# Altium schematic sheet CPU_1.SchDoc
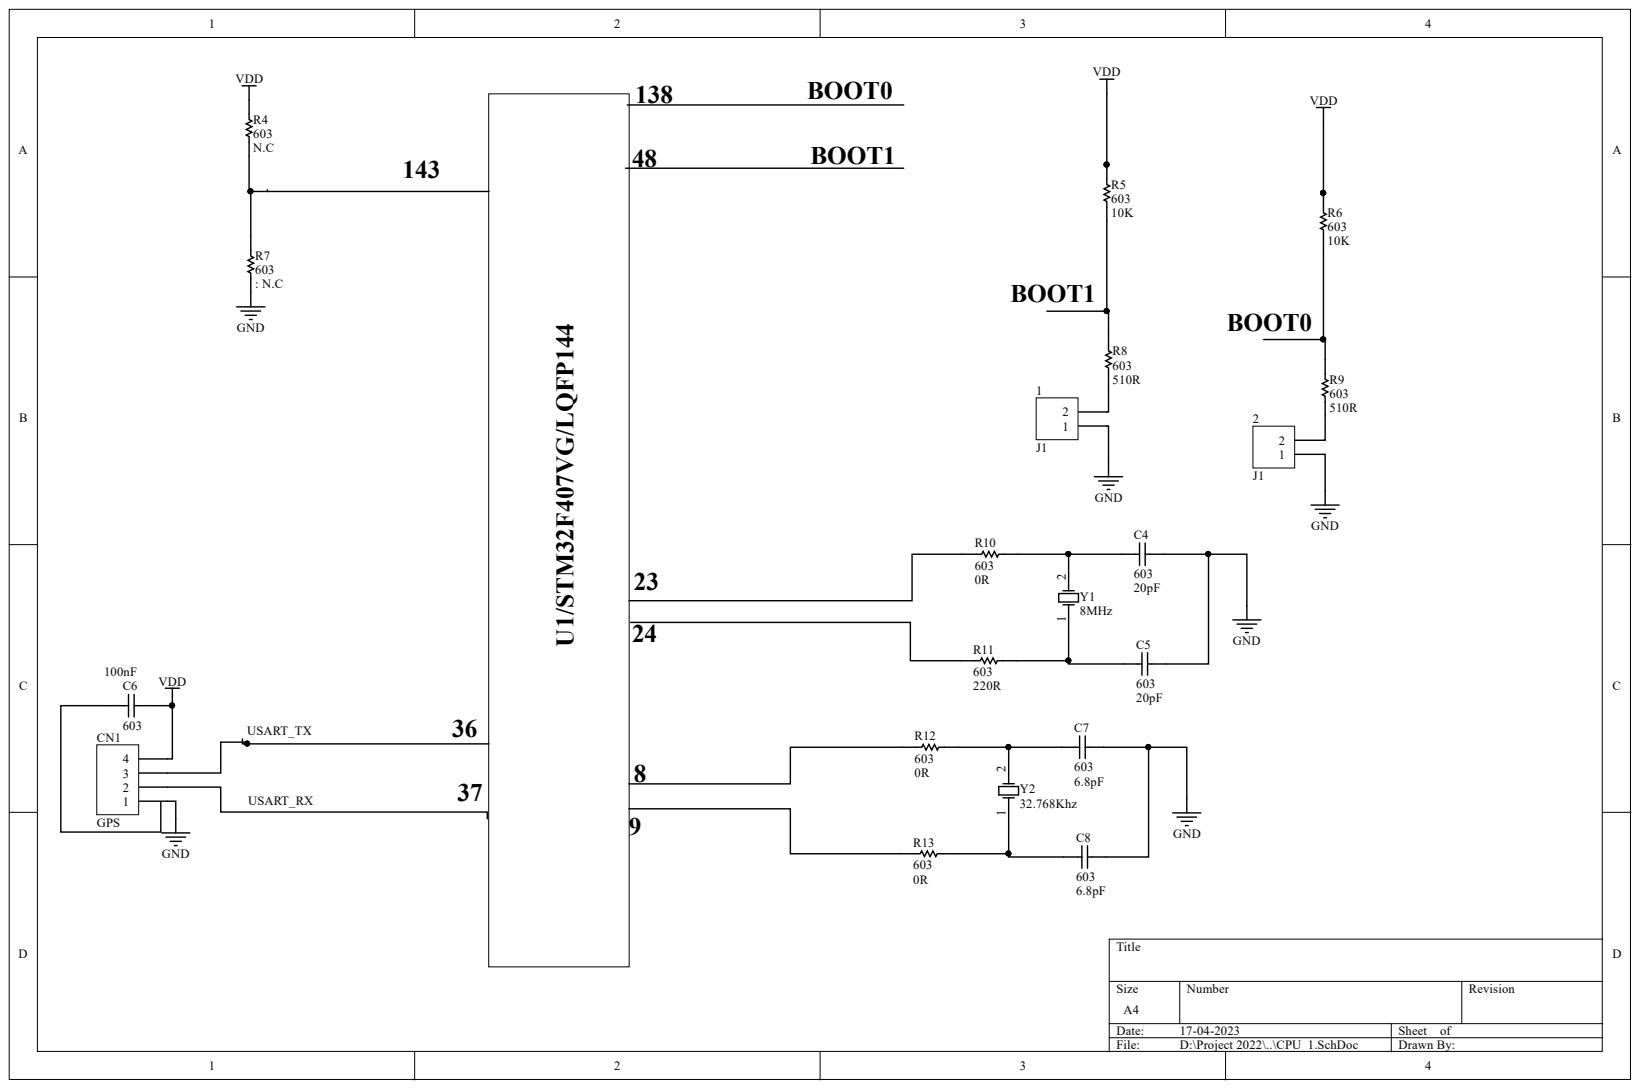
[diagram p0: CPU_1.SchDoc]

## Parts
1  J1  [HDR1X2]
2  J1  [HDR1X2]
C4  603  value 20pF  [RAD-0.3]
C5  603  value 20pF  [RAD-0.3]
C6  603  value 100nF  [RAD-0.3]
C7  603  value 6.8pF  [RAD-0.3]
C8  603  value 6.8pF  [RAD-0.3]
CN1  GPS  [HDR1X4]
R4  603  value N.C  [AXIAL-0.3]
R5  603  value 10K  [AXIAL-0.3]
R6  603  value 10K  [AXIAL-0.3]
R7  603  [AXIAL-0.3]
R8  603  value 510R  [AXIAL-0.3]
R9  603  value 510R  [AXIAL-0.3]
R10  603  value 0R  [AXIAL-0.3]
R11  603  value 220R  [AXIAL-0.3]
R12  603  value 0R  [AXIAL-0.3]
R13  603  value 0R  [AXIAL-0.3]
Y1  8MHz  [R38]
Y2  32.768Khz  [R38]

## Nets
GND: 1.1 2.1 C4.2 C5.2 C7.2 C8.2 CN1.1 R7.1
VDD: C6.2 CN1.4 R4.2 R5.2 R6.2
Net1_2: 1.2 R8.1
Net2_2: 2.2 R9.1
NetC4_1: C4.1 R10.1 Y1.2
NetC5_1: C5.1 R11.1 Y1.1
NetC7_1: C7.1 R12.1 Y2.2
NetC8_1: C8.1 R13.1 Y2.1
NetR4_1: R4.1 R7.2
NetR5_1: R5.1 R8.2
NetR6_1: R6.1 R9.2

Not connected: C6 1; CN1 2 3; R10 2; R11 2; R12 2; R13 2

## Library data of the parts
1 2 = Header 2: Header, 2-Pin; Component Kind: Standard; LatestRevisionDate: 17-Jul-2002; LatestRevisionNote: Re-released for DXP Platform.; Library Name: Miscellaneous Connectors.IntLib; Signal Integrity: Connector; Publisher: Altium Limited
C4-C8 = Cap: Capacitor; Component Kind: Standard; LatestRevisionDate: 17-Jul-2002; LatestRevisionNote: Re-released for DXP Platform.; Library Name: Miscellaneous Devices.IntLib; PackageDescription: Radial Cap, Thru-Hole; 2 Leads; 0.3 in Pin Spacing; PackageReference: RAD-0.3; Published: 8-Jun-2000; Signal Integrity: Cap; Simulation: CAP; Publisher: Altium Limited
CN1 = Header 4: Header, 4-Pin; Component Kind: Standard; LatestRevisionDate: 17-Jul-2002; LatestRevisionNote: Re-released for DXP Platform.; Library Name: Miscellaneous Connectors.IntLib; Signal Integrity: Connector; Publisher: Altium Limited
R4-R13 = Res1: Resistor; Component Kind: Standard; LatestRevisionDate: 17-Jul-2002; LatestRevisionNote: Re-released for DXP Platform.; Library Name: Miscellaneous Devices.IntLib; PackageDescription: Axial Device, Thru-Hole; 2 Leads; 0.3 in Pin Spacing; PackageReference: AXIAL-0.3; Published: 8-Jun-2000; Signal Integrity: Res; Simulation: RESISTOR; Publisher: Altium Limited
  R7: : N.C
Y1 Y2 = XTAL: Crystal Oscillator; Component Kind: Standard; LatestRevisionDate: 17-Jul-2002; LatestRevisionNote: Re-released for DXP Platform.; Library Name: Miscellaneous Devices.IntLib; PackageDescription: Crystal, Thru-Hole; 2 Leads; Body 3.1 x 8.2 mm (Dia.xH); PackageReference: R38; Published: 8-Jun-2000; Simulation: XTAL; Publisher: Altium Limited
